# Altium schematic sheet TOP.SchDoc(TOP)
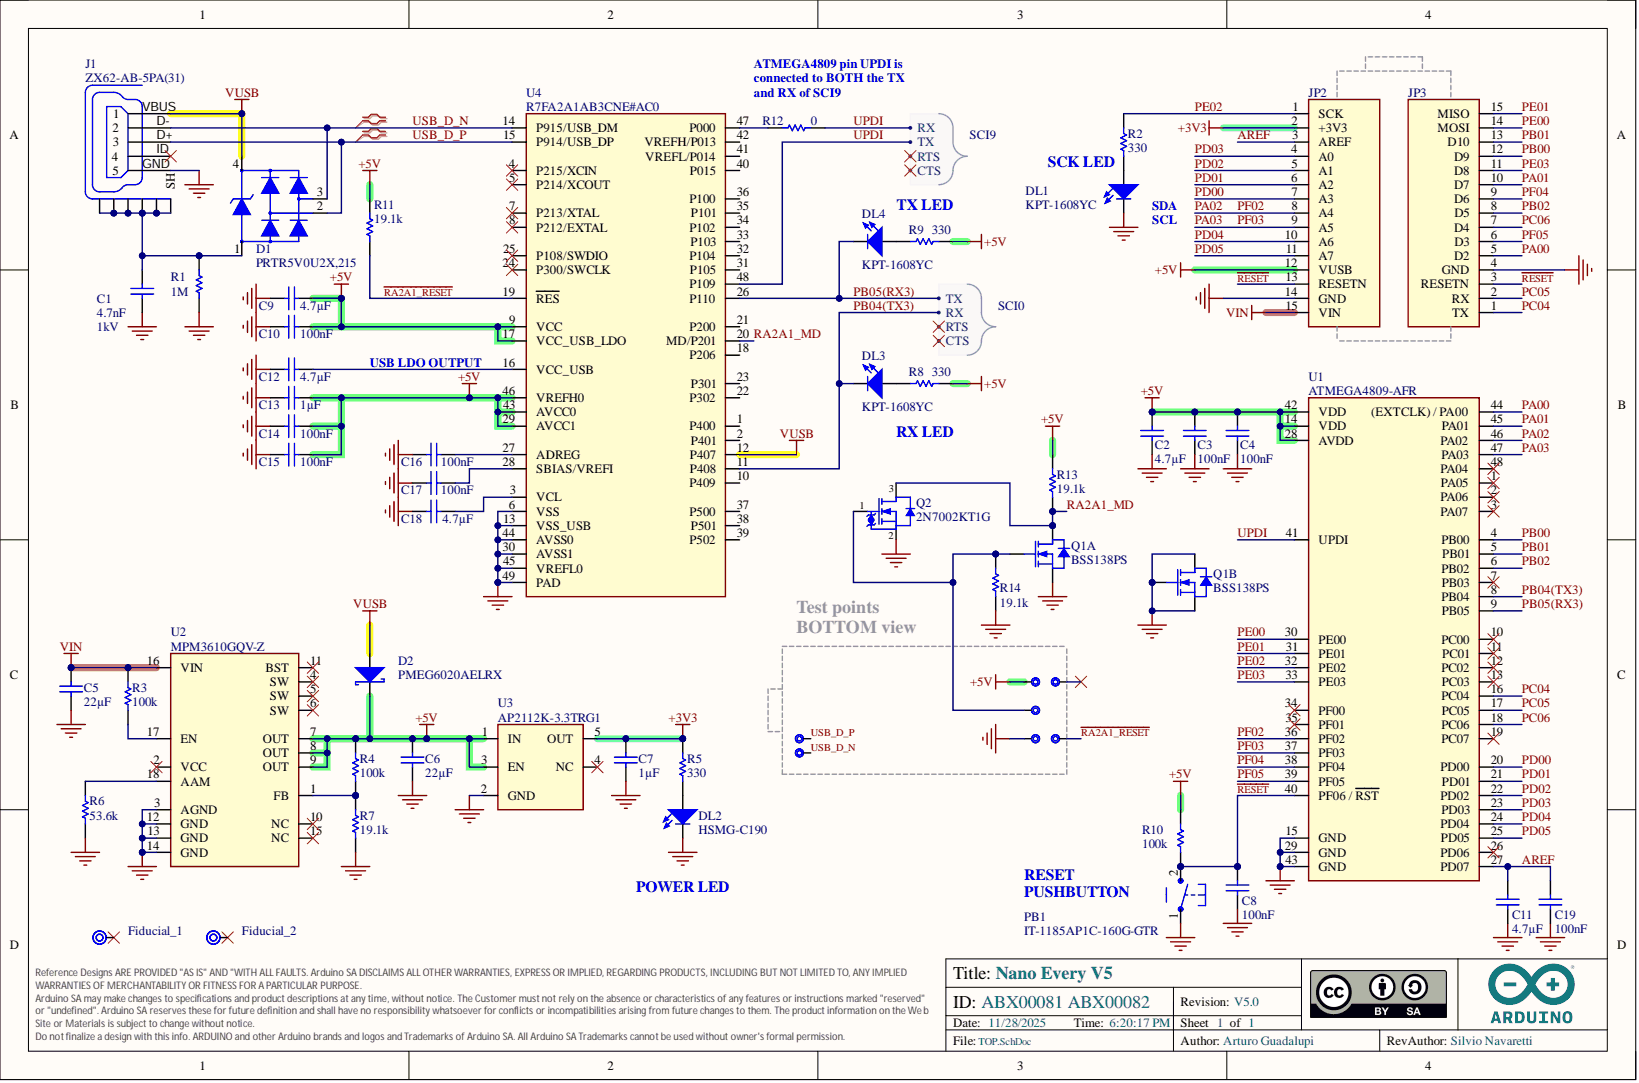
[diagram p0: TOP.SchDoc]

## Parts
C1  value 4.7nF  Voltage: 1kV  (hidden comment: FV21X472K102ECG)
C2  value 4.7µF  (hidden comment: GRM188R61C475KAAJD)
C3  value 100nF  (hidden comment: GRM155R61H104KE19D)
C4  value 100nF  (hidden comment: GRM155R61H104KE19D)
C5  value 22µF  (hidden comment: CL21A226MAQNNNE)
C6  value 22µF  (hidden comment: CL21A226MAQNNNE)
C7  value 1µF  (hidden comment: CL05A105KP5NNNC)
C8  value 100nF  (hidden comment: GRM155R61H104KE19D)
C9  value 4.7µF  (hidden comment: GRM188R61C475KAAJD)
C10  value 100nF  (hidden comment: GRM155R61H104KE19D)
C11  value 4.7µF  (hidden comment: GRM188R61C475KAAJD)
C12  value 4.7µF  (hidden comment: GRM188R61C475KAAJD)
C13  value 1µF  (hidden comment: CL05A105KP5NNNC)
C14  value 100nF  (hidden comment: GRM155R61H104KE19D)
C15  value 100nF  (hidden comment: GRM155R61H104KE19D)
C16  value 100nF  (hidden comment: GRM155R61H104KE19D)
C17  value 100nF  (hidden comment: GRM155R61H104KE19D)
C18  value 4.7µF  (hidden comment: GRM188R61C475KAAJD)
C19  value 100nF  (hidden comment: GRM155R61H104KE19D)
D1  PRTR5V0U2X,215
D2  PMEG6020AELRX
D+  CMP-018-0005-A.3  (value not drawn)  (no BOM)
D-  CMP-018-0005-A.3  (value not drawn)  (no BOM)
DL1  KPT-1608YC
DL2  HSMG-C190
DL3  KPT-1608YC
DL4  KPT-1608YC
Fiducial_1  Fiducial mark 1mm with 2mm solder  (value not drawn)  (no BOM)
Fiducial_2  Fiducial mark 1mm with 2mm solder  (value not drawn)  (no BOM)
J1  ZX62-AB-5PA(31)
JP2  PINHD-1X15-NANO-ANALOG-CASTELLATED  (value not drawn)
JP3  PINHD-1X15-NANO-DIGITAL-CASTELLATED  (value not drawn)
PB1  IT-1185AP1C-160G-GTR
Q1  BSS138PS  (parts A,B)
Q2  2N7002KT1G
R1  value 1M  (hidden comment: CRCW04021M00FKED)
R2  value 330  (hidden comment: CRCW0402330RJNED)
R3  value 100k  (hidden comment: CRCW0402100KFKEDC)
R4  value 100k  (hidden comment: CRCW0402100KFKEDC)
R5  value 330  (hidden comment: CRCW0402330RJNED)
R6  value 53.6k  (hidden comment: CRCW040253K6FKED)
R7  value 19.1k  (hidden comment: CRCW040219K1FKEDC)
R8  value 330  (hidden comment: CRCW0402330RJNED)
R9  value 330  (hidden comment: CRCW0402330RJNED)
R10  value 100k  (hidden comment: CRCW0402100KFKEDC)
R11  value 19.1k  (hidden comment: CRCW040219K1FKEDC)
R12  value 0  (hidden comment: CRCW04020000Z0EDC)
R13  value 19.1k  (hidden comment: CRCW040219K1FKEDC)
R14  value 19.1k  (hidden comment: CRCW040219K1FKEDC)
TP_5V  CMP-018-0005-A.3  (value not drawn)  (no BOM)
TP_GND  CMP-018-0005-A.3  (value not drawn)  (no BOM)
TP_MD  CMP-018-0005-A.3  (value not drawn)  (no BOM)
TP_RESETN  CMP-018-0005-A.3  (value not drawn)  (no BOM)
TP_SWDIO  CMP-018-0005-A.3  (value not drawn)  (no BOM)
U1  ATMEGA4809-AFR
U2  MPM3610GQV-Z
U3  AP2112K-3.3TRG1
U4  R7FA2A1AB3CNE#AC0

## Nets
+3V3: C7.2 JP2.2(+3V3) R5.2 U3.5(OUT)
+5V: C2.2 C3.2 C4.2 C6.2 C9.2 C10.2 C13.2 C14.2 C15.2 D2.C JP2.12(VUSB) R4.2 R8.2 R9.2 R10.2 R11.2 R13.2 TP_5V.X U1.14(VDD) U1.28(AVDD) U1.42(VDD) U2.7(OUT) U2.8(OUT) U2.9(OUT) U3.1(IN) U3.3(EN) U4.9(VCC) U4.17(VCC_USB_LDO) U4.29(AVCC1) U4.43(AVCC0) U4.46(VREFH0)
GND: C1.1 C2.1 C3.1 C4.1 C5.1 C6.1 C7.1 C8.1 C9.1 C10.1 C11.1 C12.1 C13.1 C14.1 C15.1 C16.1 C17.1 C18.1 C19.1 DL1.C DL2.C J1.5 JP2.14(GND) JP3.4(GND) PB1.1 Q1.1 Q1.3 Q1.4 Q1.5 Q2.2 R1.1 R6.1 R7.1 R14.1 TP_GND.X U1.15(GND) U1.29(GND) U1.43(GND) U2.3(AGND) U2.12(GND) U2.13(GND) U2.14(GND) U3.2(GND) U4.6(VSS) U4.13(VSS_USB) U4.30(AVSS1) U4.44(AVSS0) U4.45(VREFL0) U4.49(PAD)
VIN: C5.2 JP2.15(VIN) R3.2 U2.16(VIN)
VUSB: D1.4 D2.A J1.1 U4.12(P407)
AREF_1: C11.2 C19.2 JP2.3(AREF) U1.27(PD07)  [also labelled AREF]
PA00_1: JP3.5(D2) U1.44((EXTCLK)_/_PA00)  [also labelled PA00]
PA01_1: JP3.10(D7) U1.45(PA01)  [also labelled PA01]
PA02_1: JP2.8(A4) U1.36(PF02) U1.46(PA02)  [also labelled PA02]
PA03_1: JP2.9(A5) U1.37(PF03) U1.47(PA03)  [also labelled PA03]
PB00_1: JP3.12(D9) U1.4(PB00)  [also labelled PB00]
PB01_1: JP3.13(D10) U1.5(PB01)  [also labelled PB01]
PB02_1: JP3.8(D5) U1.6(PB02)  [also labelled PB02]
PB04(TX3)_1: DL3.C U1.8(PB04) U4.11(P408)  [also labelled PB04(TX3)]
PB05(RX3)_1: DL4.C U1.9(PB05) U4.26(P110)  [also labelled PB05(RX3)]
PC04_1: JP3.1(TX) U1.16(PC04)  [also labelled PC04]
PC05_1: JP3.2(RX) U1.17(PC05)  [also labelled PC05]
PC06_1: JP3.7(D4) U1.18(PC06)  [also labelled PC06]
PD00_1: JP2.7(A3) U1.20(PD00)  [also labelled PD00]
PD01_1: JP2.6(A2) U1.21(PD01)  [also labelled PD01]
PD02_1: JP2.5(A1) U1.22(PD02)  [also labelled PD02]
PD03_1: JP2.4(A0) U1.23(PD03)  [also labelled PD03]
PD04_1: JP2.10(A6) U1.24(PD04)  [also labelled PD04]
PD05_1: JP2.11(A7) U1.25(PD05)  [also labelled PD05]
PE00_1: JP3.14(MOSI) U1.30(PE00)  [also labelled PE00]
PE01_1: JP3.15(MISO) U1.31(PE01)  [also labelled PE01]
PE02_1: JP2.1(SCK) R2.2 U1.32(PE02)  [also labelled PE02]
PE03_1: JP3.11(D8) U1.33(PE03)  [also labelled PE03]
PF04_1: JP3.9(D6) U1.38(PF04)  [also labelled PF04]
PF05_1: JP3.6(D3) U1.39(PF05)  [also labelled PF05]
~{RA2A1_RESET}_1: R11.1 TP_RESETN.X U4.19(RES)  [also labelled ~{RA2A1_RESET}]
~{RESET}_1: C8.2 JP2.13(RESETN) JP3.3(RESETN) PB1.2 R10.1 U1.40(PF06_/_RST)  [also labelled ~{RESET}]
RA2A1_MD_1: Q1.6 Q2.3 R13.1 U4.20(MD/P201)  [also labelled RA2A1_MD]
UPDI_1: R12.2 U1.41(UPDI) U4.48(P109)  [also labelled UPDI]
USB_D_1_N: D1.3 D-.X J1.2 U4.14(P915/USB_DM)  [also labelled USB_D_N]
USB_D_1_P: D1.2 D+.X J1.3 U4.15(P914/USB_DP)  [also labelled USB_D_P]
NetC1_2: C1.2 D1.1 J1.M1 J1.M2 J1.M3 J1.M4 J1.M5 J1.M6(SH) R1.2
NetC12_2: C12.2 U4.16(VCC_USB)
NetC16_2: C16.2 U4.27(ADREG)
NetC17_2: C17.2 U4.28(SBIAS/VREFI)
NetC18_2: C18.2 U4.3(VCL)
NetDL1_A: DL1.A R2.1
NetDL2_A: DL2.A R5.1
NetDL3_A: DL3.A R8.1
NetDL4_A: DL4.A R9.1
NetQ1_2: Q1.2 Q2.1 R14.2 TP_MD.X
NetR3_1: R3.1 U2.17(EN)
NetR4_1: R4.1 R7.2 U2.1(FB)
NetR6_2: R6.2 U2.18(AAM)
NetR12_1: R12.1 U4.47(P000)

Not connected: Fiducial_1 1; Fiducial_2 1; J1 4; TP_SWDIO X; U1 1(PA05) 2(PA06) 3(PA07) 7(PB03) 10(PC00) 11(PC01) 12(PC02) 13(PC03) 19(PC07) 26(PD06) 34(PF00) 35(PF01) 48(PA04); U2 2(VCC) 4(SW) 5(SW) 6(SW) 10(NC) 11(BST) 15(NC); U3 4(NC); U4 1(P400) 2(P401) 4(P215/XCIN) 5(P214/XCOUT) 7(P213/XTAL) 8(P212/EXTAL) 10(P409) 18(P206) 21(P200) 22(P302) 23(P301) 24(P300/SWCLK) 25(P108/SWDIO) 31(P105) 32(P104) 33(P103) 34(P102) 35(P101) 36(P100) 37(P500) 38(P501) 39(P502) 40(P015) 41(VREFL/P014) 42(VREFH/P013)

## Library data of the parts
C1 = C0059-A.14: CAP CHIP 0805 4.7nF 10% 1000V X7R; Manufacturer: PSA Shenzen; Code Arduino: 0689; Case Code (Imperial): 0805; Component Kind: Standard; Dielectric: X7R; Manufacturer 2: KEMET; Manufacturer 3: KEMET; Part Number: FV21X472K102ECG; Part number 2: C0805V472KDRACTU; Part number 3: C0805C472KDRACTU; Technology: Ceramic; Tolerance: 10%; Library Name: Clean
C2 C9 C11 C12 C18 = C0054-A.16: CAP CHIP 0603 4.7uF 10% 16V X5R; Manufacturer: Murata; Code Arduino: A5072; Case Code (Imperial): 0603; Component Kind: Standard; Dielectric: X5R; Manufacturer 2: Murata; Manufacturer 3: Samsung Electro-Mechanics; Part Number: GRM188R61C475KAAJD; Part number 2: GRM188R61C475KE11D; Part number 3: CL10A475KO8NNNC; Technology: Ceramic; Tolerance: 10%; Voltage: 16V; Library Name: Clean
C3 C4 C8 C10 C14-C17 C19 = C0015-A.14: CAP CHIP 0402 100nF 10% 50V X5R; Manufacturer: Murata; Code Arduino: A5141; Case Code (Imperial): 0402; Component Kind: Standard; Dielectric: X5R; Part Number: GRM155R61H104KE19D; Technology: Ceramic; Tolerance: 10%; Voltage: 50V; Library Name: Clean
C5 C6 = C0111-A.7: CAP CHIP 0805 22uF 20% 25V X5R; Manufacturer: Samsung Electro-Mechanics; Code Arduino: C0111; Case Code (Imperial): 0805; Component Kind: Standard; Dielectric: X5R; Part Number: CL21A226MAQNNNE; Technology: Ceramic; Tolerance: 20%; Voltage: 25V; Library Name: Clean
C7 C13 = C0017-A.14: CAP CHIP 0402 1uF 10% 10V X5R; Manufacturer: Samsung Electro-Mechanics; Code Arduino: 0133; Case Code (Imperial): 0402; Component Kind: Standard; Dielectric: X5R; Manufacturer 2: Murata Electronics; Part Number: CL05A105KP5NNNC; Part number 2: GCM155C71A105KE38D; Technology: Ceramic; Tolerance: 10%; Voltage: 10V; Library Name: Clean
D1 = D0025-A.5: TVS ARRAY 4LIN.8kV 1pF SOT143B; Manufacturer: Nexperia; Code Arduino: 2209; Device Type: ESD protection; Component Kind: Standard; Part Number: PRTR5V0U2X,215; Library Name: Clean
D2 = D0026-A.6: DIODE SCHOTTKY SMD 2A 60V SOD123FL; Manucturer Part Number 2: SS26L; Manufacturer: Nexperia; Code Arduino: 2208; Forward Voltage: 0.6V; Manucturer 2: Hottech; Component Kind: Standard; Device Type: Diode Schottky; Forward Current: 2A; Part Number: PMEG6020AELRX; RoHS Compliance: RoHS3; Library Name: Clean
D+ D- TP_5V TP_GND TP_MD TP_RESETN TP_SWDIO = UT0013-A.4: Test point 1.00mm; Design Item ID: CMP-018-0005-A.3; Pin Count: 1; Source: ut.SchLib; Library Name: Clean
DL1 DL3 DL4 = L0008-A.5: LED SMD 0603 GIA588   8mcd 120^; Manufacturer: Kingbright; Code Arduino: 2243; Component Kind: Standard; Part Number: KPT-1608YC; Pin Count: 2; Library Name: Clean
DL2 = L0009-A.5: LED SMD 0603 GREEN/568 15mcd 120^; Manufacturer: Broadcom; Code Arduino: 2204; Component Kind: Standard; Part Number: HSMG-C190; Pin Count: 2; Library Name: Clean
Fiducial_1 Fiducial_2 = UT0024-A.6: Description: Fiducial mark 1mm with 2mm solder; Library Name: Clean
J1 = J0039-A.7: CONN USB MICRO F/90^ SMD TipoAB 5x1; Manufacturer: Hirose; Code Arduino: 2219; Component Kind: Standard; Part Number: ZX62-AB-5PA(31); Library Name: Clean
JP2 = J0158-A.4: CONN THD SOCKET F/1/D 15V JANALOG NANO-TYPE CASTELLATED; Code Arduino: 0646; Component Kind: Standard; Pin Count: 15; Library Name: Clean
JP3 = J0183-A.4: CONN THD SOCKET F/1/D 15V JDIGITAL NANO-TYPE CASTELLATED; Code Arduino: 0646; Component Kind: Standard; Pin Count: 15; Library Name: Clean
PB1 = B0001-A.7: PUSHBUTTON WHITE NO ANCHORS; Manufacturer: Switronic; Code Arduino: 0173; Color: White; Component Kind: Standard; Mount: SMT; Part Number: IT-1185AP1C-160G-GTR; Library Name: Clean
Q1 = T0012-A.8: MOSFET N-CH 2x 60V 0.32A SOT363; Manufacturer: Nexperia; Code Arduino: 2323; Device Type: Mosfet 2N-CH SMD; Component Kind: Standard; Part Number: BSS138PS; Pin Count: 6; Library Name: Clean
Q2 = T0004-A.9: MOSFET N-CH 60V 300mA ESD prot. SOT23; Manufacturer: On Semiconductor; Code Arduino: A5393; Device Type: Mosfet N-CH SMD; Component Kind: Standard; Manufacturer 2: Hottech; Manufacturer Part Number 2: 2N7002K; Part Number: 2N7002KT1G; Pin Count: 3; Library Name: Clean
R1 = R0058-A.24: RES CHIP 0402 1M 1% 1/16W; Manufacturer: Vishay; Code Arduino: 0139; Power: 62.5mW; Temperature coefficient: 100ppm; Case Code (Imperial): 0402; Component Kind: Standard; Part Number: CRCW04021M00FKED; Technology: Thick film; Tolerance: 1%; Library Name: Clean
R2 R5 R8 R9 = R0017-A.24: RES CHIP 0402 330R 5% 1/16W; Manufacturer: Vishay; Code Arduino: 2229; Power: 62.5mW; Temperature coefficient: 200ppm; Case Code (Imperial): 0402; Component Kind: Standard; Part Number: CRCW0402330RJNED; Technology: Thick film; Tolerance: 5%; Library Name: Clean
R3 R4 R10 = R0001-A.24: RES CHIP 0402 100k 1% 1/16W; Manufacturer: Vishay; Code Arduino: 0134; Power: 62.5mW; Temperature coefficient: 100ppm; Case Code (Imperial): 0402; Component Kind: Standard; Part Number: CRCW0402100KFKEDC; Technology: Thick film; Tolerance: 1%; Library Name: Clean
R6 = R0050-A.24: RES CHIP 0402 53k6 1% 1/16W; Manufacturer: Vishay; Code Arduino: A5313; Power: 62.5mW; Temperature coefficient: 100ppm; Case Code (Imperial): 0402; Component Kind: Standard; Part Number: CRCW040253K6FKED; Technology: Thick film; Tolerance: 1%; Library Name: Clean
R7 R11 R13 R14 = R0033-A.24: RES CHIP 0402 19k1 1% 1/16W; Manufacturer: Vishay; Code Arduino: A5369; Power: 62.5mW; Temperature coefficient: 100ppm; Case Code (Imperial): 0402; Component Kind: Standard; Part Number: CRCW040219K1FKEDC; Technology: Thick film; Tolerance: 1%; Library Name: Clean
R12 = R0000-A.24: RES CHIP 0402 0R 1/16W; Manufacturer: Vishay; Code Arduino: 0039; Power: 62.5mW; Temperature coefficient: 0ppm; Tolerance: 0%; Case Code (Imperial): 0402; Component Kind: Standard; Part Number: CRCW04020000Z0EDC; Technology: Thick film; Library Name: Clean
U1 = U0039-A.7: Manufacturer: Microchip; Code Arduino: ATMEGA4809-AFR; Component Kind: Standard; Description: ATMEGA4809-AFR; Part Number: ATMEGA4809-AFR; Library Name: Clean
U2 = U0075-A.13: IC Buck with integrated inductor 4.5 to 21V max 1.2A QFN20 3x5x1.6mm; Manufacturer: MPS; Code Arduino: 2093; Component Kind: Standard; Part Number: MPM3610GQV-Z; Library Name: Clean
U3 = U0027-A.11: IC LDO Vin max 6.5V Vout 3V3 Iout 600mA +EN  SOT25; Manufacturer: Diodes Inc; Code Arduino: 2199; Component Kind: Standard; Part Number: AP2112K-3.3TRG1; Library Name: Clean
U4 = U0258-A.7: IC uC 32b 256k +8k flash 32k RAM 1V8 to 5.5V QFN48; Manufacturer: Renesas; Code Arduino: U0258; Component Kind: Standard; Part Number: R7FA2A1AB3CNE#AC0; Library Name: Clean
